# Altium schematic sheet IO.SchDoc(IO)
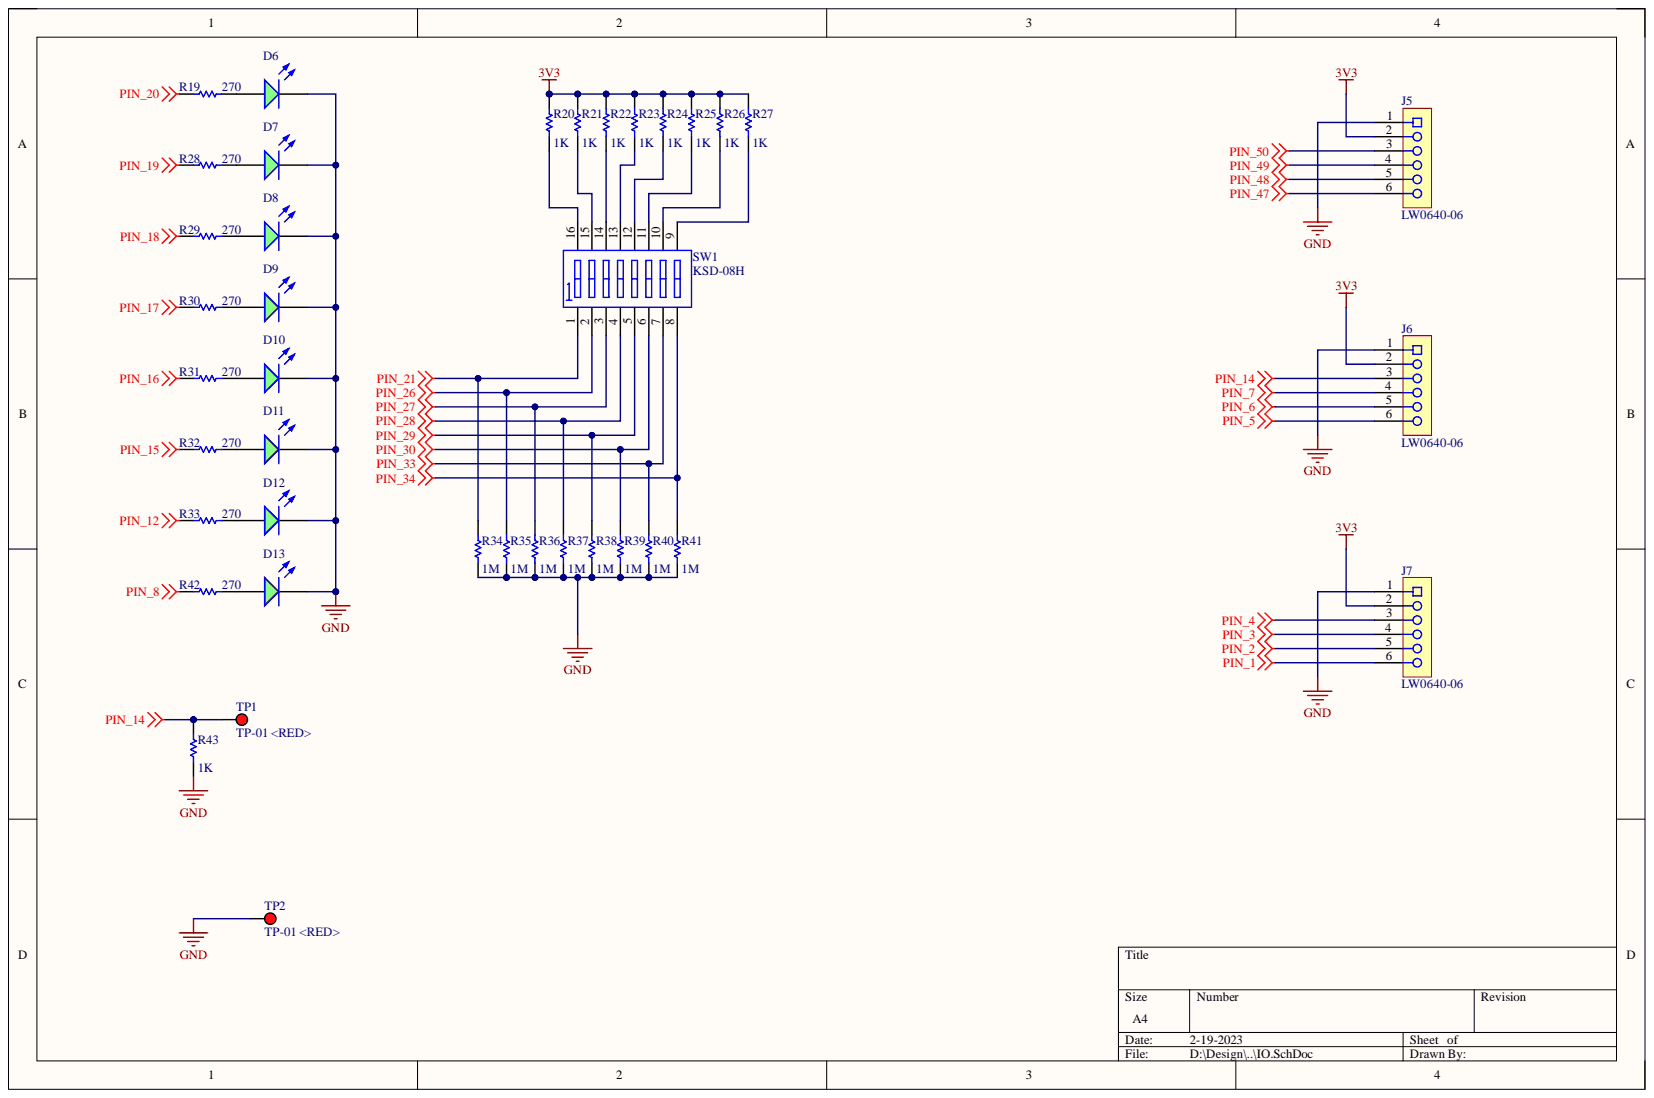
[diagram p0: IO.SchDoc]

## Parts
D6  3mm Green LED  [3mm Green LED-Footprint-1]  (value not drawn)
D7  3mm Green LED  [3mm Green LED-Footprint-1]  (value not drawn)
D8  3mm Green LED  [3mm Green LED-Footprint-1]  (value not drawn)
D9  3mm Green LED  [3mm Green LED-Footprint-1]  (value not drawn)
D10  3mm Green LED  [3mm Green LED-Footprint-1]  (value not drawn)
D11  3mm Green LED  [3mm Green LED-Footprint-1]  (value not drawn)
D12  3mm Green LED  [3mm Green LED-Footprint-1]  (value not drawn)
D13  3mm Green LED  [3mm Green LED-Footprint-1]  (value not drawn)
J5  LW0640-06  [LW0640-06]
J6  LW0640-06  [LW0640-06]
J7  LW0640-06  [LW0640-06]
R19  value 270  [Resistor 1608-Footprint]  (hidden comment: Resistor/1608)
R20  value 1K  [Resistor 1608-Footprint]  (hidden comment: Resistor/1608)
R21  value 1K  [Resistor 1608-Footprint]  (hidden comment: Resistor/1608)
R22  value 1K  [Resistor 1608-Footprint]  (hidden comment: Resistor/1608)
R23  value 1K  [Resistor 1608-Footprint]  (hidden comment: Resistor/1608)
R24  value 1K  [Resistor 1608-Footprint]  (hidden comment: Resistor/1608)
R25  value 1K  [Resistor 1608-Footprint]  (hidden comment: Resistor/1608)
R26  value 1K  [Resistor 1608-Footprint]  (hidden comment: Resistor/1608)
R27  value 1K  [Resistor 1608-Footprint]  (hidden comment: Resistor/1608)
R28  value 270  [Resistor 1608-Footprint]  (hidden comment: Resistor/1608)
R29  value 270  [Resistor 1608-Footprint]  (hidden comment: Resistor/1608)
R30  value 270  [Resistor 1608-Footprint]  (hidden comment: Resistor/1608)
R31  value 270  [Resistor 1608-Footprint]  (hidden comment: Resistor/1608)
R32  value 270  [Resistor 1608-Footprint]  (hidden comment: Resistor/1608)
R33  value 270  [Resistor 1608-Footprint]  (hidden comment: Resistor/1608)
R34  value 1M  [Resistor 1608-Footprint]  (hidden comment: Resistor/1608)
R35  value 1M  [Resistor 1608-Footprint]  (hidden comment: Resistor/1608)
R36  value 1M  [Resistor 1608-Footprint]  (hidden comment: Resistor/1608)
R37  value 1M  [Resistor 1608-Footprint]  (hidden comment: Resistor/1608)
R38  value 1M  [Resistor 1608-Footprint]  (hidden comment: Resistor/1608)
R39  value 1M  [Resistor 1608-Footprint]  (hidden comment: Resistor/1608)
R40  value 1M  [Resistor 1608-Footprint]  (hidden comment: Resistor/1608)
R41  value 1M  [Resistor 1608-Footprint]  (hidden comment: Resistor/1608)
R42  value 270  [Resistor 1608-Footprint]  (hidden comment: Resistor/1608)
R43  value 1K  [Resistor 1608-Footprint]  (hidden comment: Resistor/1608)
SW1  KSD-08H  [New footprint 1]
TP1  TP-01 <RED>  [TP-01-Footprint-RED]
TP2  TP-01 <RED>  [TP-01-Footprint-RED]

## Nets
3V3: J5.2 J6.2 J7.2 R20.2 R21.2 R22.2 R23.2 R24.2 R25.2 R26.2 R27.2
GND: D6.2 D7.2 D8.2 D9.2 D10.2 D11.2 D12.2 D13.2 J5.1 J6.1 J7.1 R34.1 R35.1 R36.1 R37.1 R38.1 R39.1 R40.1 R41.1 R43.1 TP2.1
PIN_1: J7.6
PIN_2: J7.5
PIN_3: J7.4
PIN_4: J7.3
PIN_5: J6.6
PIN_6: J6.5
PIN_7: J6.4
PIN_8: R42.1
PIN_12: R33.1
PIN_14: J6.3 R43.2 TP1.1
PIN_15: R32.1
PIN_16: R31.1
PIN_17: R30.1
PIN_18: R29.1
PIN_19: R28.1
PIN_20: R19.1
PIN_21: R34.2 SW1.1
PIN_26: R35.2 SW1.2
PIN_27: R36.2 SW1.3
PIN_28: R37.2 SW1.4
PIN_29: R38.2 SW1.5
PIN_30: R39.2 SW1.6
PIN_33: R40.2 SW1.7
PIN_34: R41.2 SW1.8
PIN_47: J5.6
PIN_48: J5.5
PIN_49: J5.4
PIN_50: J5.3
NetD6_1: D6.1 R19.2
NetD7_1: D7.1 R28.2
NetD8_1: D8.1 R29.2
NetD9_1: D9.1 R30.2
NetD10_1: D10.1 R31.2
NetD11_1: D11.1 R32.2
NetD12_1: D12.1 R33.2
NetD13_1: D13.1 R42.2
NetR20_1: R20.1 SW1.16
NetR21_1: R21.1 SW1.15
NetR22_1: R22.1 SW1.14
NetR23_1: R23.1 SW1.13
NetR24_1: R24.1 SW1.12
NetR25_1: R25.1 SW1.11
NetR26_1: R26.1 SW1.10
NetR27_1: R27.1 SW1.9

## Library data of the parts
D6-D13 = CMP-008-0013-2: Generic 3mm LED <GREEN>; Component Kind: Standard; Library Name: C6H6`s Vault
J5-J7 = CMP-003-0008-4: 6-pin Molex 5045 compatible Hanlim Connector; Component Kind: Standard; Library Name: C6H6`s Vault; Pin Count: 6
R19-R43 = CMP-001-0018-2: Generic 1608 Resistor; Case/Package: Bulk; Component Kind: Standard; Library Name: C6H6`s Vault; Mount: SMD; Pins: 2; RoHS: Yes
SW1 = CMP-ROOT-00028-3: 2.54mm Code Switch 8p THT; Case/Package: DIP; Component Kind: Standard; Library Name: C6H6`s Vault; Mount: THT; Pins: 16; RoHS: Yes
TP1 TP2 = CMP-001-A01-3: TP-01 Red testpoint; Component Kind: Standard; Library Name: C6H6`s Vault
